# Altium schematic sheet mbedXdotShield-V_1_2_0_3.SchDoc(mbedXdotShield-V_1_2_0_3)
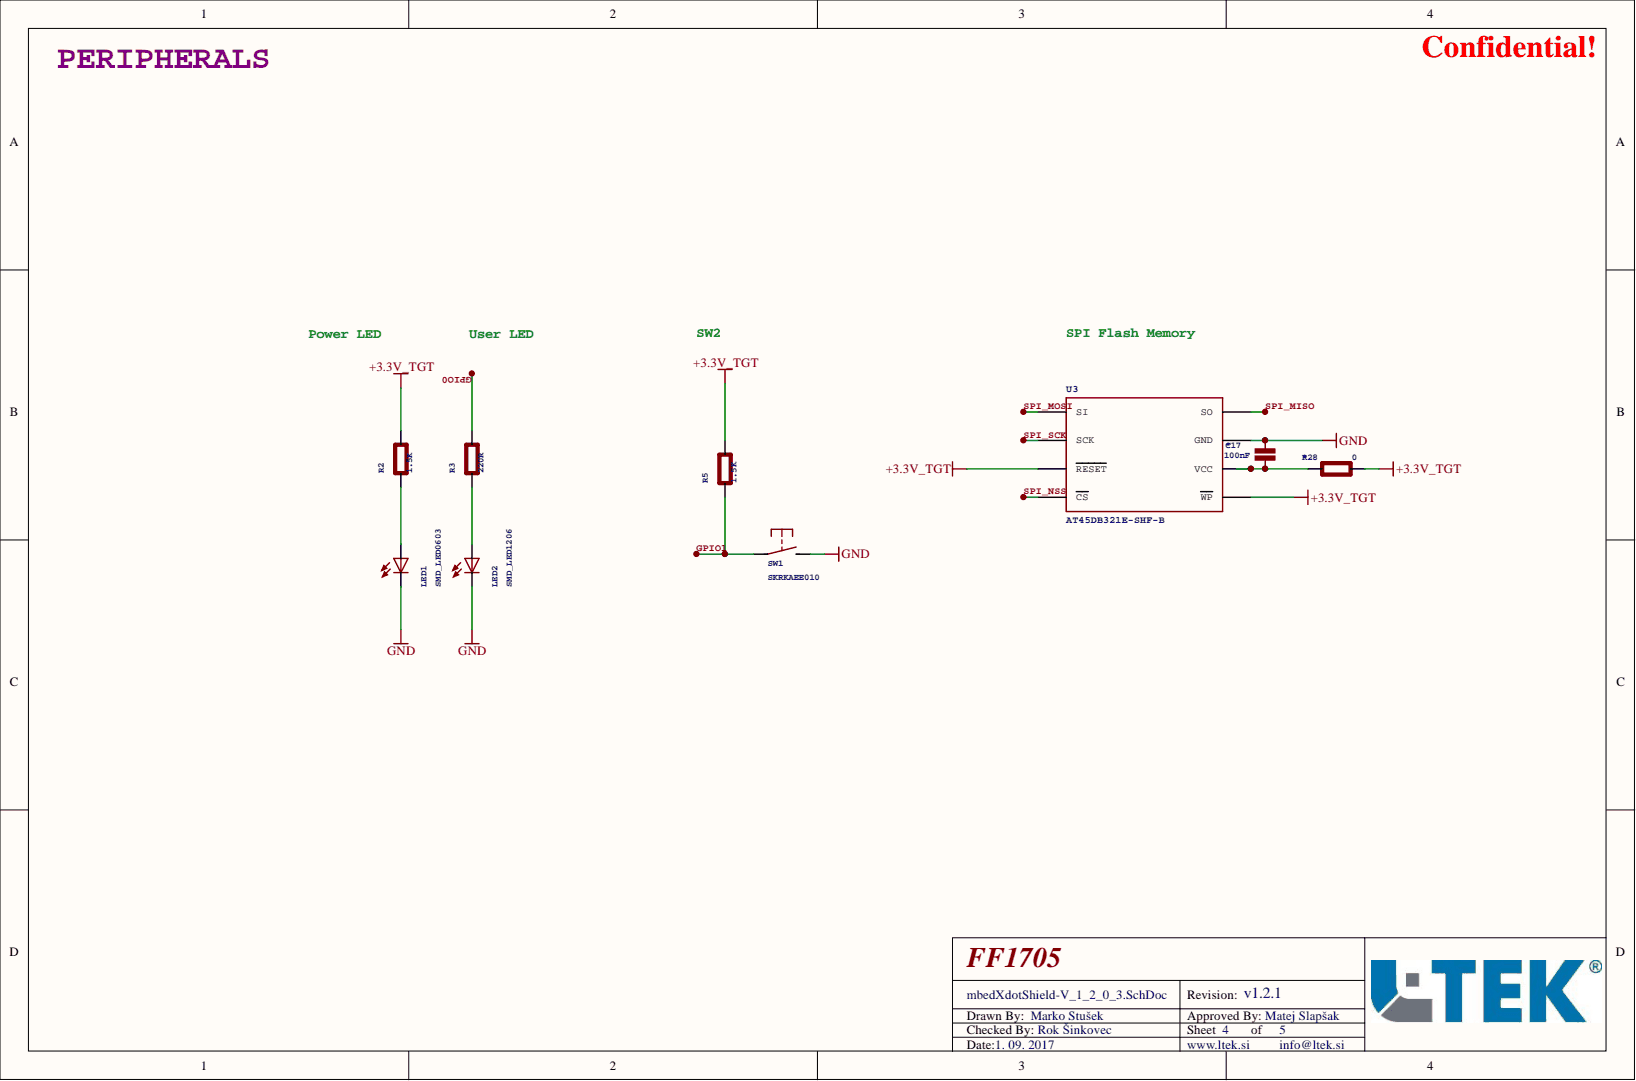
[diagram p0: mbedXdotShield-V_1_2_0_3.SchDoc]

## Parts
C17  100nF  [C0603]
LED1  SMD_LED0603  [CHIPLED_0603]
LED2  SMD_LED1206  [CHIPLED_1206]
R2  1.5K  [0402]
R3  220R  [0402]
R5  1.5K  [0402]
R28  0  [0402]
SW1  SKRKAEE010  [SKRKAEE010]
U3  AT45DB321E-SHF-B  [SO8]

## Nets
+3.3V_TGT: R2.2 R5.2 R28.2 U3.3(RESET) U3.5(WP)
GND: C17.1 LED1.C LED2.C SW1.P$2 U3.7(GND)
GPIO0: R3.2
GPIO1: R5.1 SW1.P$1
SPI_MISO: U3.8(SO)
SPI_MOSI: U3.1(SI)
SPI_NSS: U3.4(CS)
SPI_SCK: U3.2(SCK)
NetC17_2: C17.2 R28.1 U3.6(VCC)
NetLED1_A: LED1.A R2.1
NetLED2_A: LED2.A R3.1

## Library data of the parts
C17 = CAPACITOR0603: Capacitor; Component Kind: Standard; Library Name: mbed-HDK-RLCQ.IntLib
LED1 = SMD_LED0603: LED SMD, Colour Red; MFN: 150060RS75000; MF: Wurth Electronics Inc; OC-NEWARK: 2322071; OC-MOUSER: 710-150060RS75000; OC-DIGIKEY: 732-4978-1-ND; Component Kind: Standard; Library Name: mbed-HDK-Optical.IntLib
LED2 = SMD_LED1206: LED SMD, Colour Red; MFN: 150120GS75000; MF: Wurth Electronics Inc; OC-NEWARK: 2322085; OC-MOUSER: 710-150120GS75000; OC-DIGIKEY: 732-4990-1-ND; Component Kind: Standard; Library Name: mbed-HDK-Optical.IntLib
R2 R3 R5 R28 = RESISTOR0402: Resistor; Component Kind: Standard; Library Name: mbed-HDK-RLCQ.IntLib
SW1 = SKRKAEE010: MOMENTARY SWITCH PUSH VERTICAL (SPST); MF: ALPS; OC-MOUSER: 688-SKRKAE; MFN: SKRKAEE010; Component Kind: Standard; Library Name: mbed-HDK-Switch.IntLib
U3 = AT45DB321E-SHF-B: Serial Flash 32 Mb 85 Mhz; MF: Adesto Technologies; OC-NEWARK: 2414318; OC-MOUSER: 988-AT45DB321E-SHF-B; OC-DIGIKEY: 1265-1072-5-ND; MFN: AT45DB321E-SHF-B; Component Kind: Standard; Library Name: mbed-HDK-IC.IntLib
